# Altium schematic sheet Peripherals.SchDoc
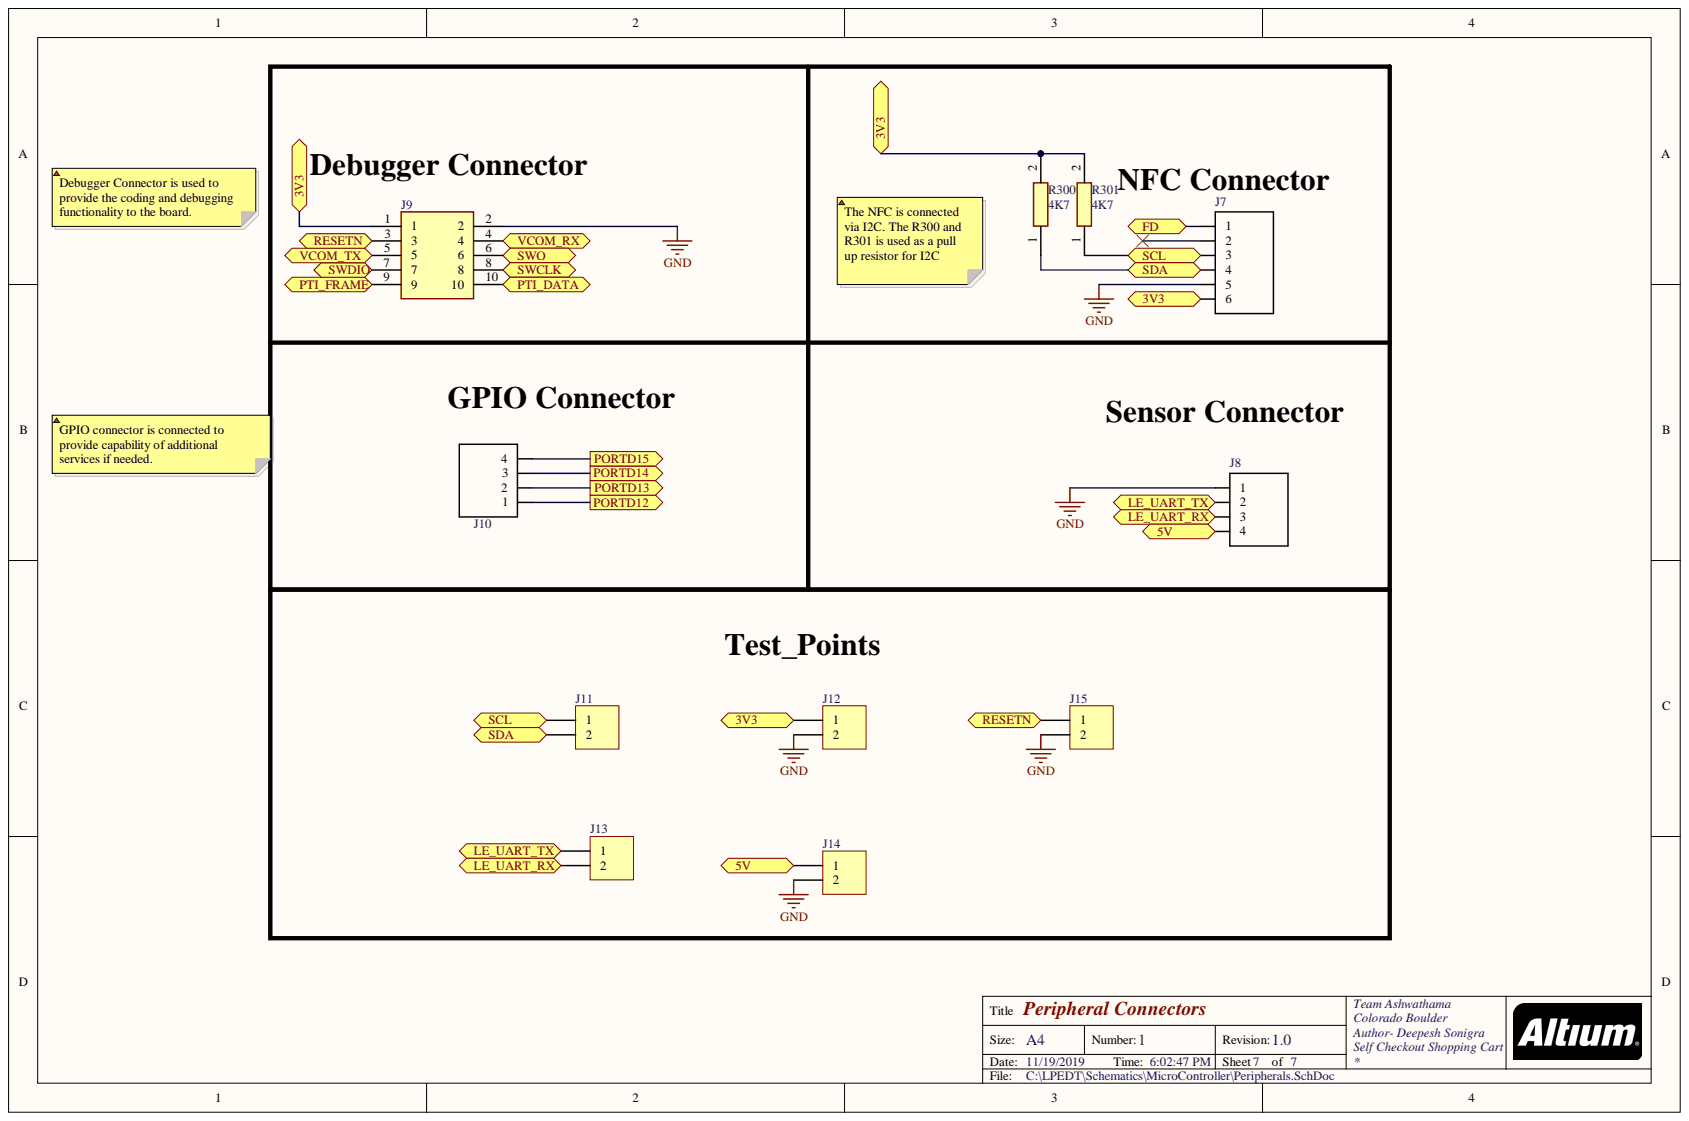
[diagram p0: Peripherals.SchDoc]

## Parts
J7  BG300-06-X-X-A_REVC  [*GCT_BG300-06-X-X-A_REVC]  (value not drawn)
J8  BG301-04-X-XXXX-X-X_REVC  [*GCT_BG301-04-X-XXXX-X-X_REVC]  (value not drawn)
J9  FTSH-105-01-L-DV-K-TR  [FTSH10501LDVKTR]  (value not drawn)
J10  BG301-04-X-XXXX-X-X_REVC  [*GCT_BG301-04-X-XXXX-X-X_REVC]  (value not drawn)
J11  Header 2  [HDR1X2]  (value not drawn)
J12  Header 2  [HDR1X2]  (value not drawn)
J13  Header 2  [HDR1X2]  (value not drawn)
J14  Header 2  [HDR1X2]  (value not drawn)
J15  Header 2  [HDR1X2]  (value not drawn)
R300  value 4K7  [RESC1608X50N]  (hidden comment: RCS06034K70FKEA)
R301  value 4K7  [RESC1608X50N]  (hidden comment: RCS06034K70FKEA)

## Ports
3V3, 5V, FD, LE_UART_RX, LE_UART_TX, PORTD12, PORTD13, PORTD14, PORTD15, PTI_DATA, PTI_FRAME, RESETN, SCL, SDA, SWCLK, SWDIO, SWO, VCOM_RX, VCOM_TX

## Nets
GND: J7.5 J8.1 J9.2 J12.2 J14.2 J15.2
3V3: J7.6 J9.1 J12.1 R300.2 R301.2 ; port 3V3
5V: J8.4 J14.1 ; port 5V
FD: J7.1 ; port FD
LE_UART_RX: J8.3 J13.2 ; port LE_UART_RX
LE_UART_TX: J8.2 J13.1 ; port LE_UART_TX
PORTD12: J10.1 ; port PORTD12
PORTD13: J10.2 ; port PORTD13
PORTD14: J10.3 ; port PORTD14
PORTD15: J10.4 ; port PORTD15
PTI_DATA: J9.10 ; port PTI_DATA
PTI_FRAME: J9.9 ; port PTI_FRAME
RESETN: J9.3 J15.1 ; port RESETN
SCL: J7.3 J11.1 R301.1 ; port SCL
SDA: J7.4 J11.2 R300.1 ; port SDA
SWCLK: J9.8 ; port SWCLK
SWDIO: J9.7 ; port SWDIO
SWO: J9.6 ; port SWO
VCOM_RX: J9.4 ; port VCOM_RX
VCOM_TX: J9.5 ; port VCOM_TX

Not connected: J7 2

## Library data of the parts
J7 = BG300-06-X-X-A_REVC: 6 POS Right Angle Header; Manufacturer_Name: Global Connector Technology; Manufacturer_Part_Number: BG300-06-A-L-A; Detailed Description: 6 Position Receptacle Connector Surface Mount, Right Angle; Component Kind: Standard; Digi-Key Part Number: 2073-BG300-06-A-L-ACT-ND; Digikey Price/Stock: https://www.digikey.com/product-detail/en/gct/BG300-06-A-L-A/2073-BG300-06-A-L-ACT-ND/9859667?utm_source=snapeda&utm_medium=aggregator&utm_campaign=symbol; Library Name: BG300-06-X-X-A_REVC.IntLib
J8 J10 = BG301-04-X-XXXX-X-X_REVC: 4 POS Right Angle Header; Manufacturer_Name: Global Connector Technology; Manufacturer_Part_Number: BG301-04-A-0540-L-B; Component Kind: Standard; Digi-Key Part Number: 2073-BG301-04-A-0540-L-BCT-ND; Digikey Price/Stock: https://www.digikey.com/product-detail/en/gct/BG301-04-A-0540-L-B/2073-BG301-04-A-0540-L-BCT-ND/9859670?utm_source=snapeda&utm_medium=aggregator&utm_campaign=symbol; Library Name: BG301-04-X-XXXX-X-X_REVC.IntLib
J9 = FTSH-105-01-L-DV-K-TR: Connector; Manufacturer_Name: SAMTEC; Component Kind: Standard; Datasheet Link: http://suddendocs.samtec.com/catalog_english/ftsh_th.pdf; Height: 5.56mm; Manufacturer_Part_Number: FTSH-105-01-L-DV-K-TR; Mouser Part Number: 200-FTSH10501LDVKTR; Mouser Price/Stock: https://www.mouser.com/Search/Refine.aspx?Keyword=200-FTSH10501LDVKTR; RS Part Number: 1803002P; RS Price/Stock: http://uk.rs-online.com/web/p/products/1803002P
J11-J15 = Header 2: Header, 2-Pin; Manufacturer_Name: Global Connector Technology; Manufacturer_Part_Number: BG301-04-A-0540-L-B; Component Kind: Standard; Digi-Key Part Number: 2073-BG301-02-A-0540-L-BCT-ND; Digikey Price/Stock: https://www.digikey.com/product-detail/en/gct/BG301-04-A-0540-L-B/2073-BG301-04-A-0540-L-BCT-ND/9859670?utm_source=snapeda&utm_medium=aggregator&utm_campaign=symbol; Library Name: Miscellaneous Connectors.IntLib; Signal Integrity: Connector
R300 R301 = RCS06034K70FKEA: Resistor; Manufacturer_Name: Vishay; Component Kind: Standard; Datasheet Link: https://www.vishay.com/docs/20065/rcse3.pdf; Height: 0.5mm; Manufacturer_Part_Number: RCS06034K70FKEA; Mouser Part Number: 71-RCS06034K70FKEA; Mouser Price/Stock: https://www.mouser.com/Search/Refine.aspx?Keyword=71-RCS06034K70FKEA
